# Altium schematic sheet SDCARD.SchDoc(SDCARD)
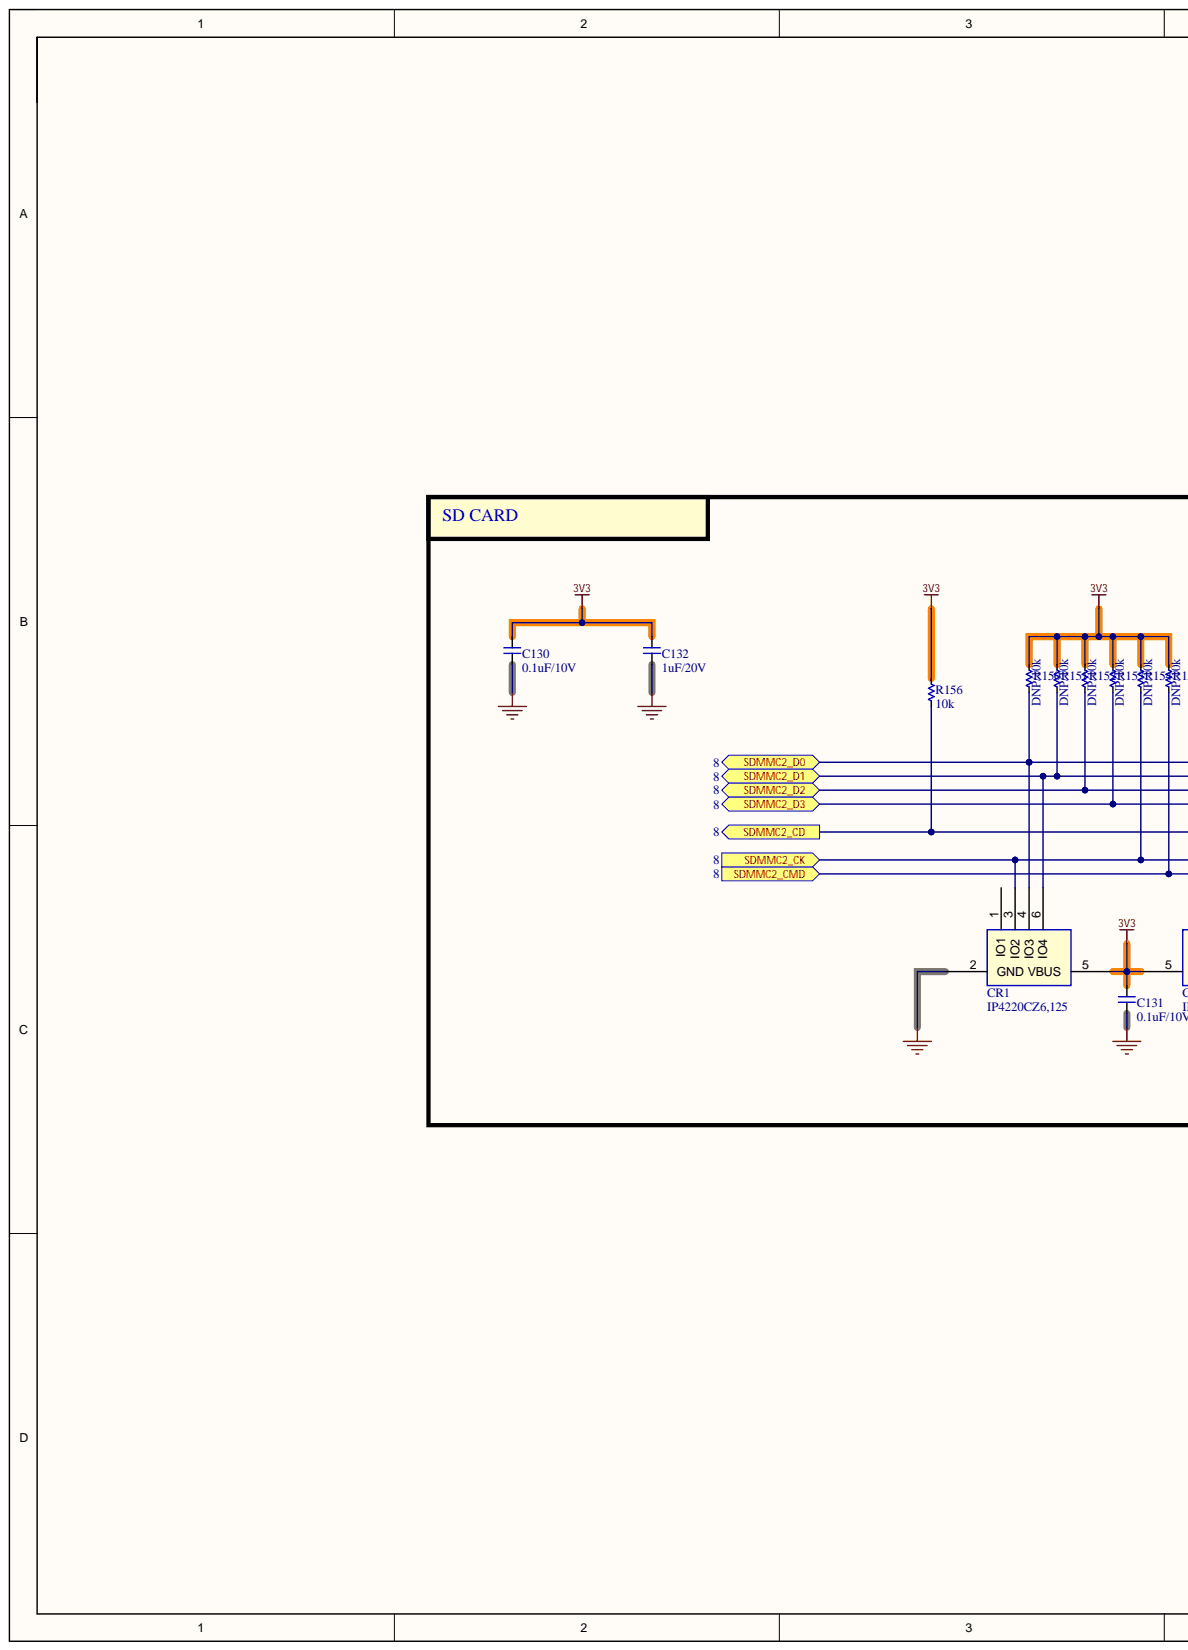
[diagram p0: SDCARD.SchDoc, left]
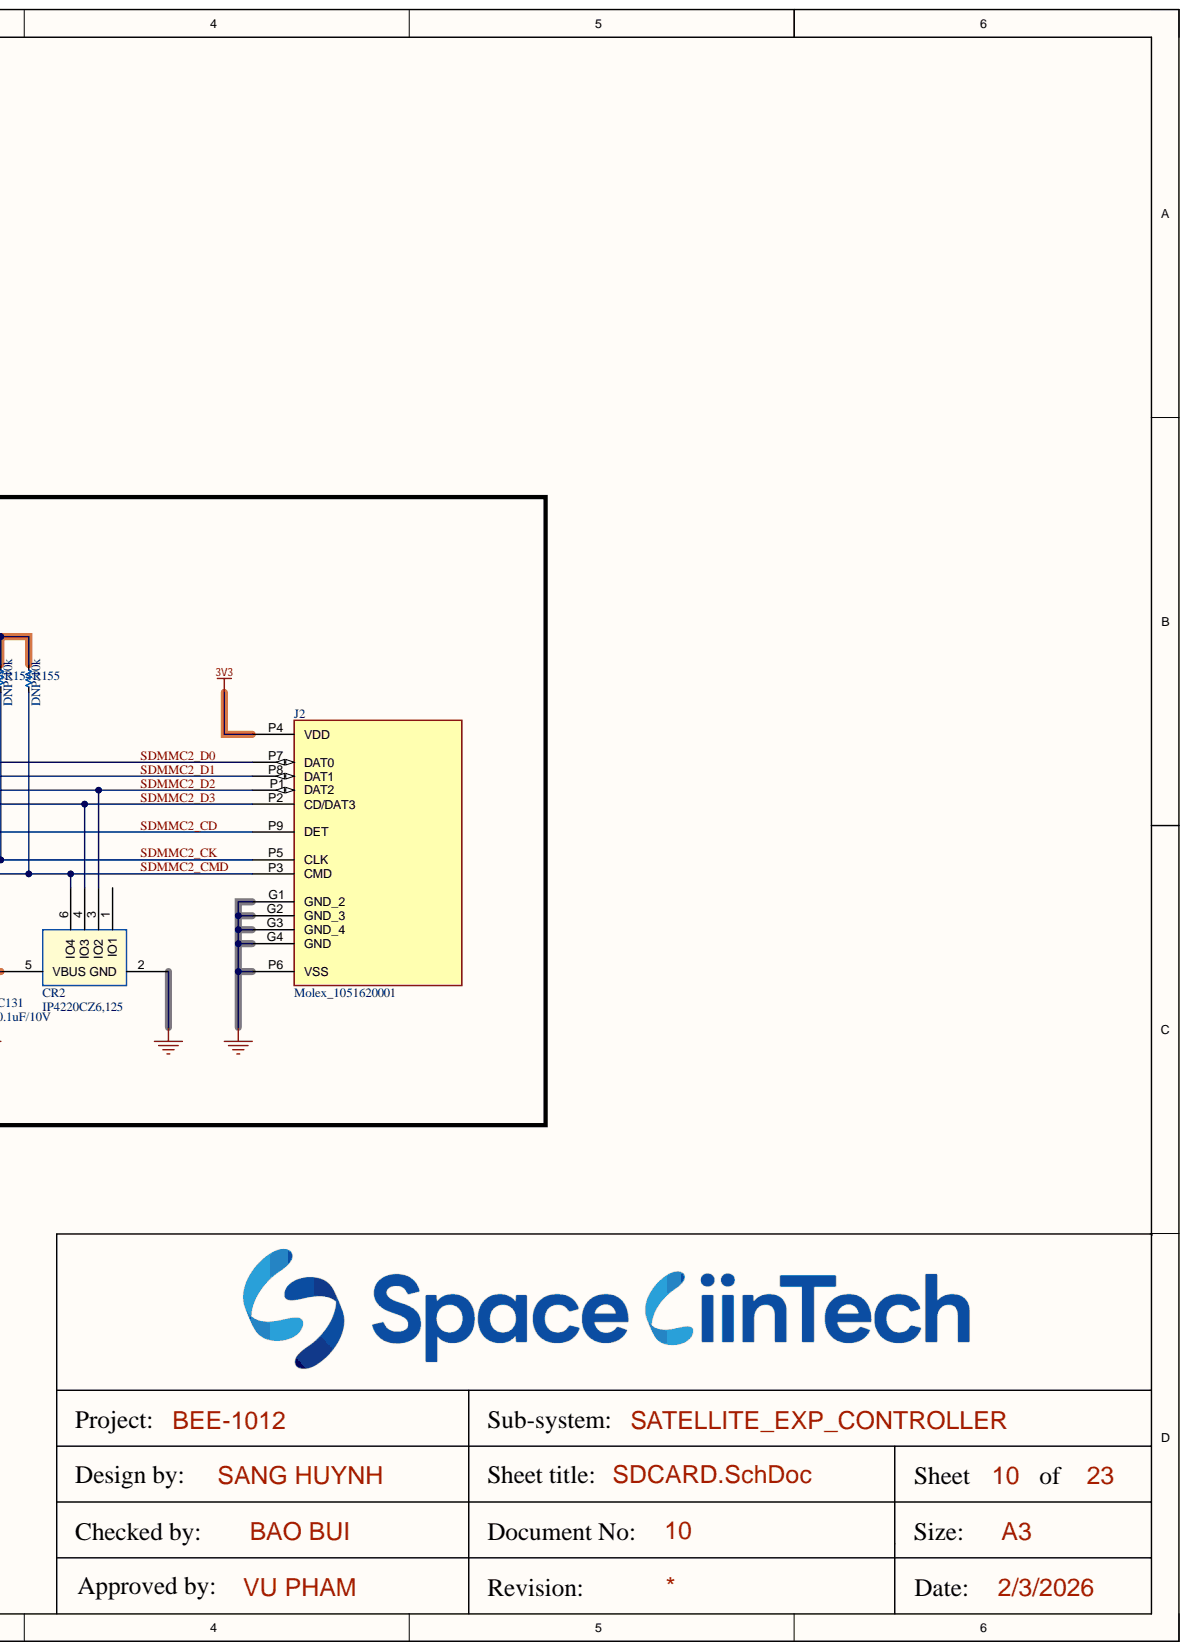
[diagram p1: SDCARD.SchDoc, right]

## Parts
C130  0.1uF/10V  [CAP_C_1005X50_L]
C131  0.1uF/10V  [CAP_C_1005X50_L]
C132  1uF/20V  [CAP_C_1005X50_L]
CR1  IP4220CZ6,125  [DIODE_SOT457_1P7X3P1_NEX]
CR2  IP4220CZ6,125  [DIODE_SOT457_1P7X3P1_NEX]
J2  Molex_1051620001  [MOLEX_1051620001]
R150  DNP/10k  [RES_C_1005x30_L]
R151  DNP/10k  [RES_C_1005x30_L]
R152  DNP/10k  [RES_C_1005x30_L]
R153  DNP/10k  [RES_C_1005x30_L]
R154  DNP/10k  [RES_C_1005x30_L]
R155  DNP/10k  [RES_C_1005x30_L]
R156  10k  [RES_C_1005x30_L]

## Ports
SDMMC2_CD, SDMMC2_CK, SDMMC2_CMD, SDMMC2_D0, SDMMC2_D1, SDMMC2_D2, SDMMC2_D3

## Nets
3V3: C130.2 C131.2 C132.2 CR1.5(VBUS) CR2.5(VBUS) J2.P4(VDD) R150.2 R151.2 R152.2 R153.2 R154.2 R155.2 R156.2
GND: C130.1 C131.1 C132.1 CR1.2(GND) CR2.2(GND) J2.G1(GND_2) J2.G2(GND_3) J2.G3(GND_4) J2.G4(GND) J2.P6(VSS)
SDMMC2_CD: J2.P9(DET) R156.1 ; port SDMMC2_CD
SDMMC2_CK: CR1.3(IO2) J2.P5(CLK) R154.1 ; port SDMMC2_CK
SDMMC2_CMD: CR2.6(IO4) J2.P3(CMD) R155.1 ; port SDMMC2_CMD
SDMMC2_D0: CR1.4(IO3) J2.P7(DAT0) R150.1 ; port SDMMC2_D0
SDMMC2_D1: CR1.6(IO4) J2.P8(DAT1) R151.1 ; port SDMMC2_D1
SDMMC2_D2: CR2.3(IO2) J2.P1(DAT2) R152.1 ; port SDMMC2_D2
SDMMC2_D3: CR2.4(IO3) J2.P2(CD/DAT3) R153.1 ; port SDMMC2_D3

Not connected: CR1 1(IO1); CR2 1(IO1)

## Library data of the parts
C130-C132 = _CAP_C_2: CAP CHIP; Component Kind: Standard; Library Name: IFBschelib.SchLib
CR1 CR2 = IP4220CZ6,125: TVS DIODE 5.5VWM 6TSOP; Manufacturer_Name: Nexperia; Component Kind: Standard; Manufacturer_Part_Number: IP4220CZ6,125; RefDes: RefDes; Type: Type; Copyright: Copyright (C) 2025 Ultra Librarian. All rights reserved.; Library Name: IFBschelib.SchLib
J2 = Molex_1051620001: 9 (8 + 1) Position Card Connector Secure Digital - microSDâ„¢ Surface Mount, Right Angle Gold; MF: Molex; Check_prices: https://www.snapeda.com/parts/1051620001/Molex/view-part/?ref=eda; Component Kind: Standard; MP: 1051620001; Package: None; SnapEDA_Link: https://www.snapeda.com/parts/1051620001/Molex/view-part/?ref=snap; Library Name: IFBschelib.SchLib
R150-R156 = _RES_B_2: RES; Component Kind: Standard; Library Name: IFBschelib.SchLib
